# Altium schematic sheet Schematic_GenericNode_1.SchDoc
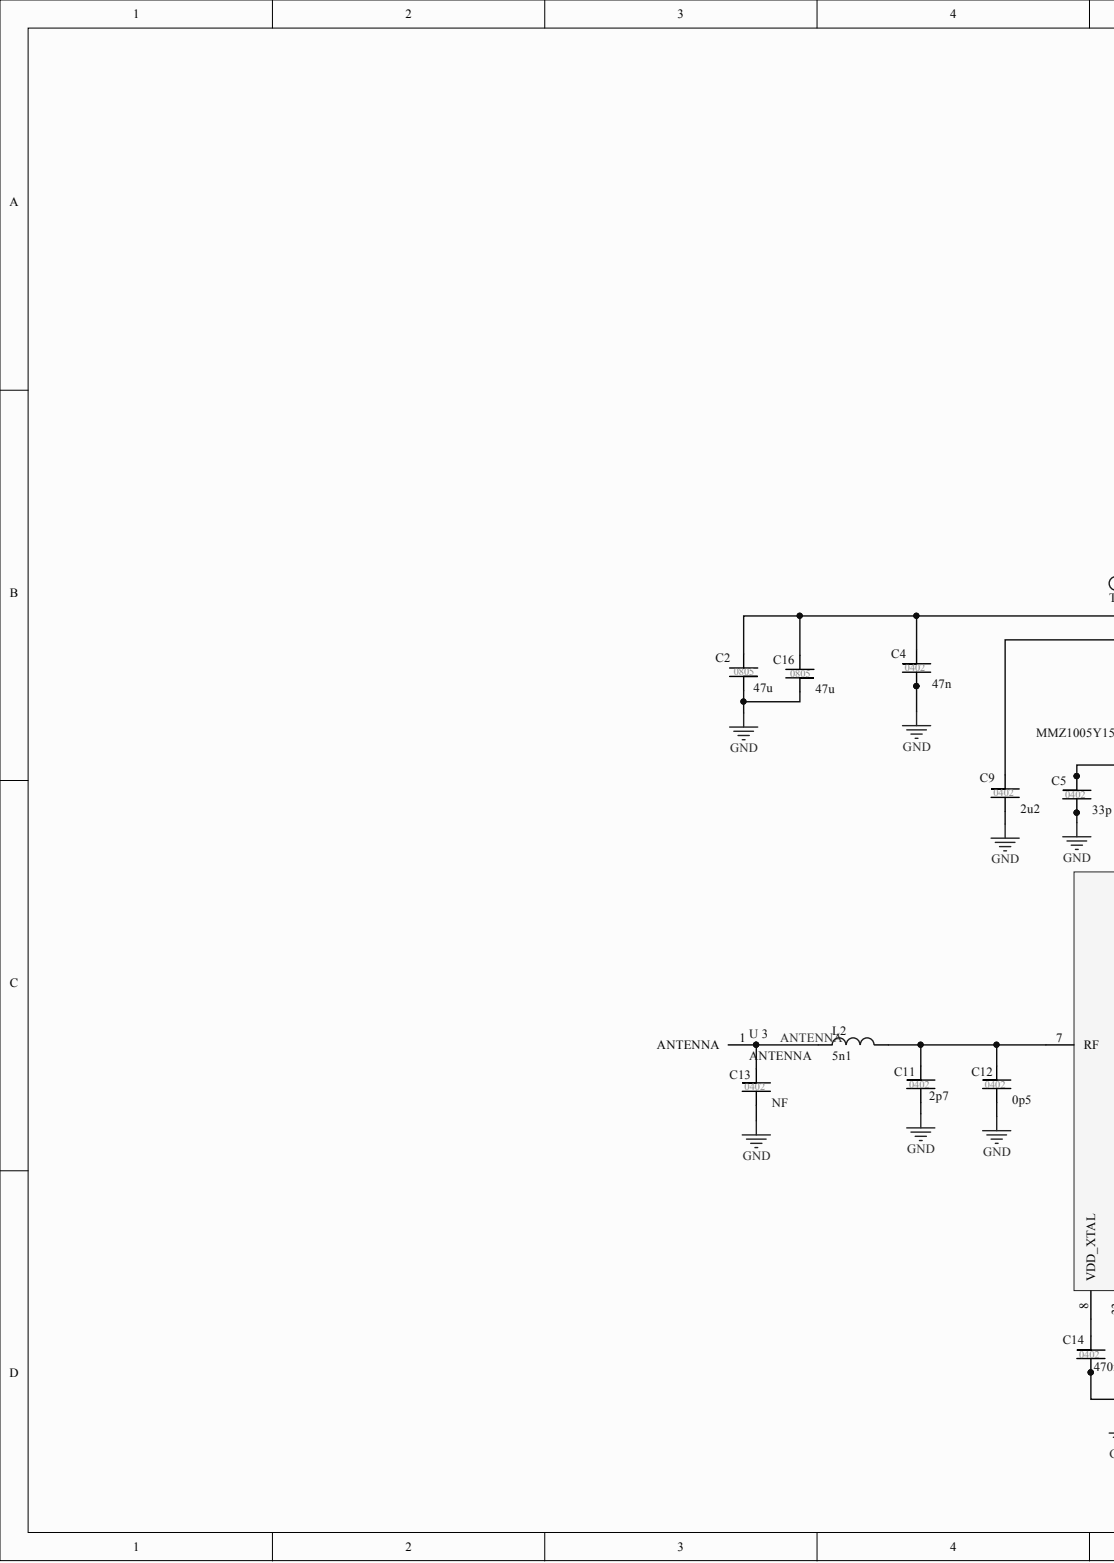
[diagram p0: Schematic_GenericNode_1.SchDoc, left]
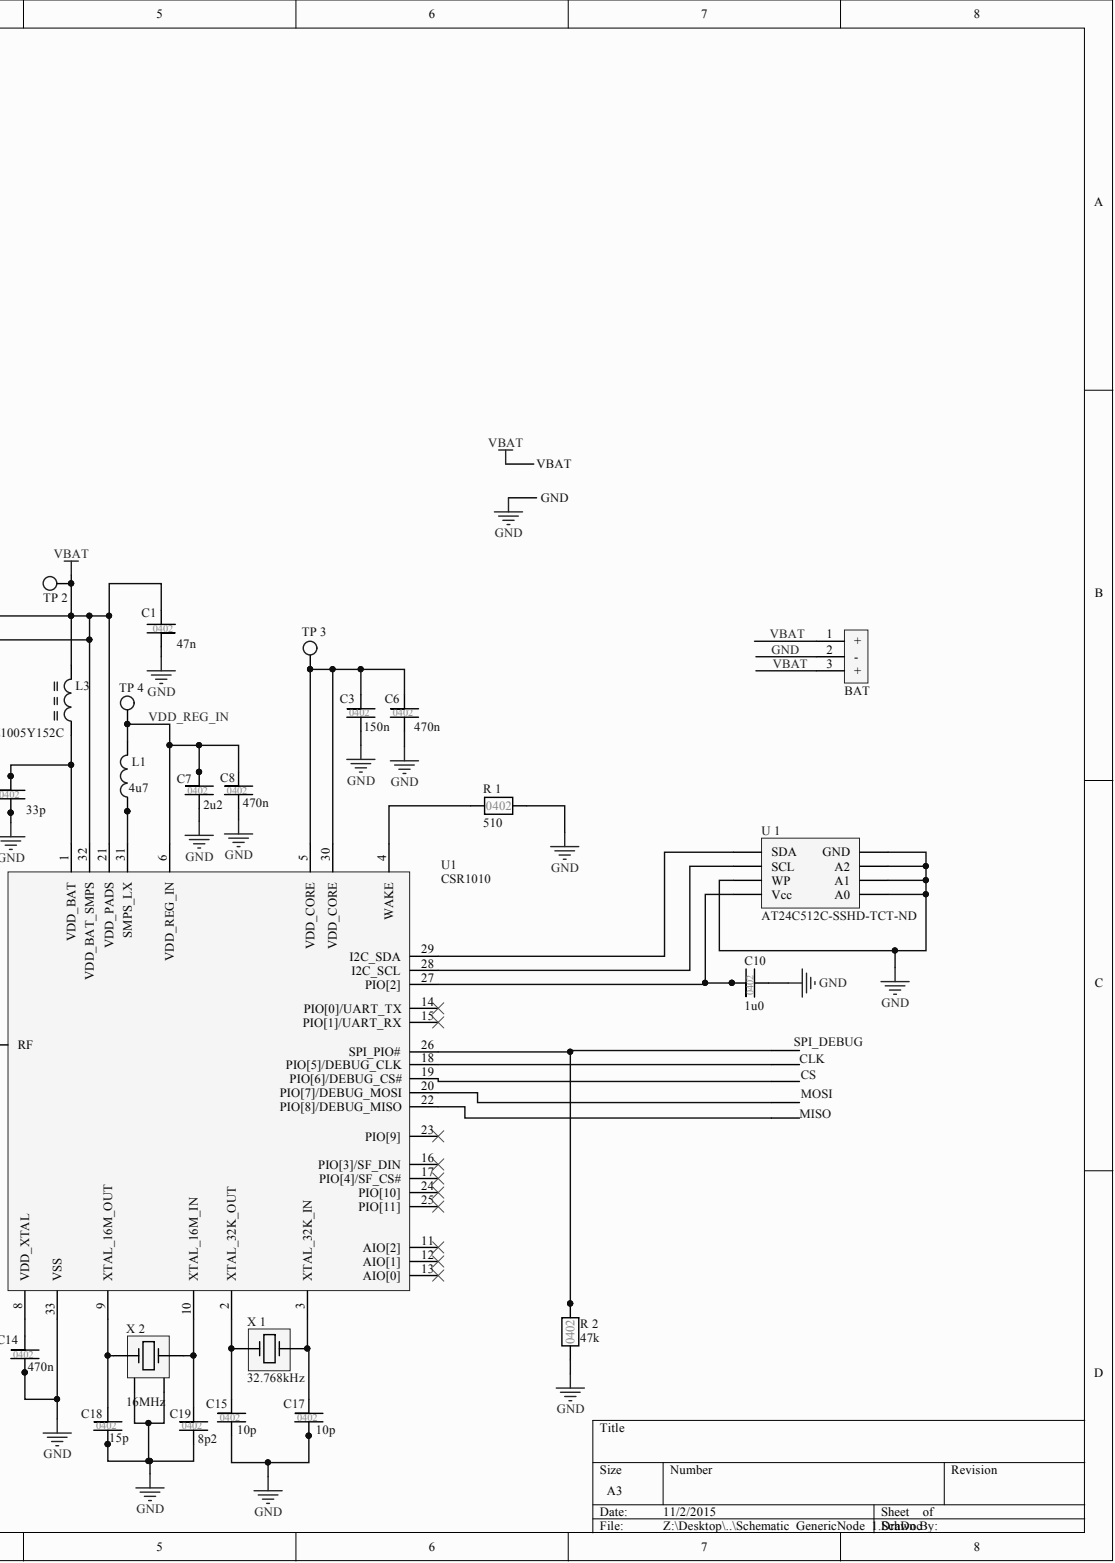
[diagram p1: Schematic_GenericNode_1.SchDoc, right]

## Parts
BAT  HOLDER COIN CELL 20MM SMD  [HOLDER COIN CELL 20MM SMD]  (value not drawn)
C1  47n  [C0402]
C2  47u  [C0805]
C3  150n  [C0402]
C4  47n  [C0402]
C5  33p  [C0402]
C6  470n  [C0402]
C7  2u2  [C0402]
C8  470n  [C0402]
C9  2u2  [C0402]
C10  1u0  [C0402]
C11  2p7  [C0402]
C12  0p5  [C0402]
C13  NF  [C0402]
C14  470n  [C0402]
C15  10p  [C0402]
C16  47u  [C0805]
C17  10p  [C0402]
C18  15p  [C0402]
C19  8p2  [C0402]
CLK  ProgPin  [ProgPin]  (value not drawn)
CS  ProgPin  [ProgPin]  (value not drawn)
GND  (no value)  [ProgPin]
L1  4u7  [L1210- Power Inductor]
L2  5n1  [L0402]
L3  MMZ1005Y152C  [MMZ1005Y152C]
MISO  ProgPin  [ProgPin]  (value not drawn)
MOSI  ProgPin  [ProgPin]  (value not drawn)
R_1  510  [R0402]
R_2  47k  [R0402]
SPI_DEBUG  ProgPin  [ProgPin]  (value not drawn)
TP_2  Test Point  [TP]  (value not drawn)
TP_3  Test Point  [TP]  (value not drawn)
TP_4  Test Point  [TP]  (value not drawn)
U1  CSR1010  [32-lead-QFN-5x5x0.6mm]
U_1  AT24C512C-SSHD-TCT-ND  [8-SOIC]
U_3  ANTENNA  [ANTENNA]
VBAT  (no value)  [ProgPin]
X_1  32.768kHz  [32.7KHZ]
X_2  16MHz  [16Mhz]

## Nets
ANTENNA: C13.2 L2.1 U_3.1
GND: BAT.2 C1.2 C2.2 C3.1 C4.1 C5.1 C6.1 C7.1 C8.1 C9.1 C10.1 C11.1 C12.1 C13.1 C14.1 C15.1 C16.2 C17.1 C18.1 C19.1 GND.1 R_1.2 R_2.2 U1.33(VSS) U_1.1(A0) U_1.2(A1) U_1.3(A2) U_1.4(GND) U_1.7(WP) X_2.2 X_2.4
VBAT: BAT.1 BAT.3 C1.1 C2.1 C4.2 C9.2 C16.1 L3.2 TP_2.1 U1.21(VDD_PADS) U1.32(VDD_BAT_SMPS) VBAT.1
VDD_REG_IN: C7.2 C8.2 L1.2 TP_4.1 U1.6(VDD_REG_IN)
NetC3_2: C3.2 C6.2 TP_3.1 U1.5(VDD_CORE) U1.30(VDD_CORE)
NetC5_2: C5.2 L3.1 U1.1(VDD_BAT)
NetC10_2: C10.2 U1.27(PIO[2]) U_1.8(Vcc)
NetC11_2: C11.2 C12.2 L2.2 U1.7(RF)
NetC14_2: C14.2 U1.8(VDD_XTAL)
NetC15_2: C15.2 U1.2(XTAL_32K_OUT) X_1.1
NetC17_2: C17.2 U1.3(XTAL_32K_IN) X_1.4
NetC18_2: C18.2 U1.9(XTAL_16M_OUT) X_2.1
NetC19_2: C19.2 U1.10(XTAL_16M_IN) X_2.3
NetCLK_1: CLK.1 U1.18(PIO[5]/DEBUG_CLK)
NetCS_1: CS.1 U1.19(PIO[6]/DEBUG_CS#)
NetL1_1: L1.1 U1.31(SMPS_LX)
NetMISO_1: MISO.1 U1.22(PIO[8]/DEBUG_MISO)
NetMOSI_1: MOSI.1 U1.20(PIO[7]/DEBUG_MOSI)
NetR 1_1: R_1.1 U1.4(WAKE)
NetR 2_1: R_2.1 SPI_DEBUG.1 U1.26(SPI_PIO#)
NetU 1_5: U1.29(I2C_SDA) U_1.5(SDA)
NetU 1_6: U1.28(I2C_SCL) U_1.6(SCL)

Not connected: U1 11(AIO[2]) 12(AIO[1]) 13(AIO[0]) 14(PIO[0]/UART_TX) 15(PIO[1]/UART_RX) 16(PIO[3]/SF_DIN) 17(PIO[4]/SF_CS#) 23(PIO[9]) 24(PIO[10]) 25(PIO[11])

## Library data of the parts
C1 C3-C15 C17-C19 = C, 0402: Component Kind: Standard; Library Name: Schlib_GenericNode_1.SchLib
C2 C16 = C, 0805: Component Kind: Standard; Library Name: Schlib_GenericNode_1.SchLib
GND VBAT = ProgPin: Component Kind: Standard; Library Name: Schlib_GenericNode_1.SchLib
L1 = 1210 Ferrite: Component Kind: Standard; Library Name: Schlib_GenericNode_1.SchLib
L2 = 0402, Inductor: Component Kind: Standard; Library Name: Schlib_GenericNode_1.SchLib
R_1 R_2 = R, 0402: Component Kind: Standard; Library Name: Schlib_GenericNode_1.SchLib
TP_2-TP_4 = Test Point: Test Points; Component Kind: Standard; Library Name: Schlib_Ceresense_4-4.SchLib
BAT = HOLDER COIN CELL 20MM SMD: Component Kind: Standard; Description: HOLDER COIN CELL 20MM SMD; Library Name: Schlib_Ceresense_4-4.SchLib
CLK CS MISO MOSI SPI_DEBUG = ProgPin: Component Kind: Standard; Library Name: Schlib_GenericNode_1.SchLib
L3 = MMZ1005Y152C: Component Kind: Standard; Library Name: Schlib_GenericNode_1.SchLib
U1 = CSR1010: Component Kind: Standard; Library Name: Schlib_Ceresense_4-4.SchLib
U_1 = AT24C512C-SSHD-TCT-ND: Component Kind: Standard; Description: AT24C512C-SSHD-TCT-ND; Library Name: Schlib_Ceresense_4-4.SchLib
U_3 = ANTENNA: Component Kind: Standard; Library Name: Schlib_GenericNode_1.SchLib
X_1 = 32.768kHz: Component Kind: Standard; Library Name: Schlib_Ceresense_4-4.SchLib
X_2 = 16Mhz: Component Kind: Standard; Library Name: Schlib_GenericNode_1.SchLib
